# Altium schematic sheet Sensor.SchDoc
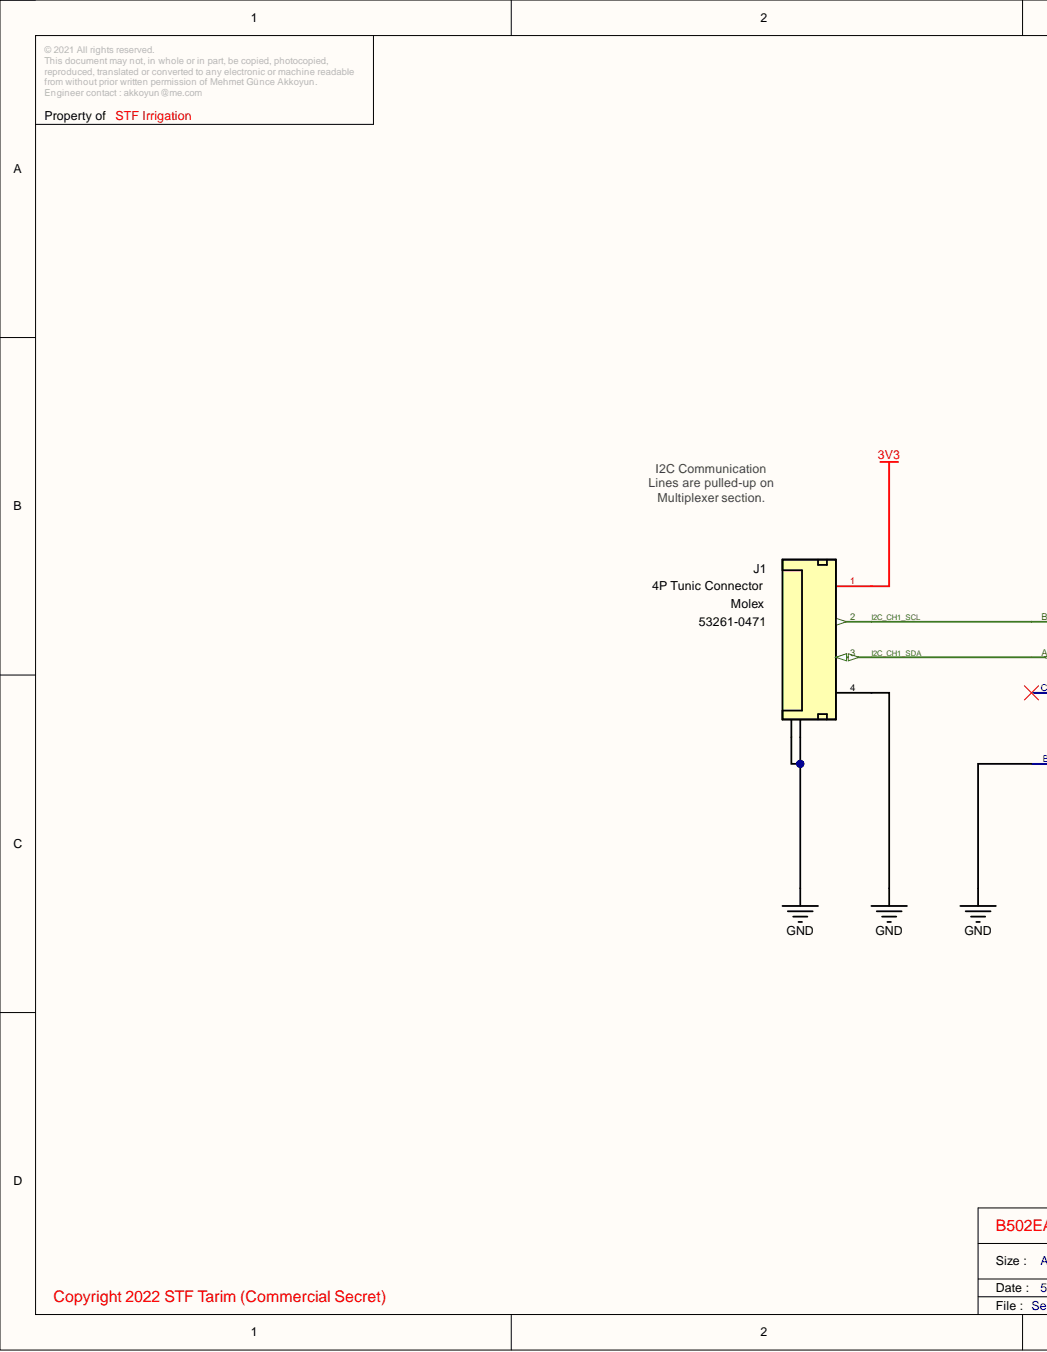
[diagram p0: Sensor.SchDoc, left]
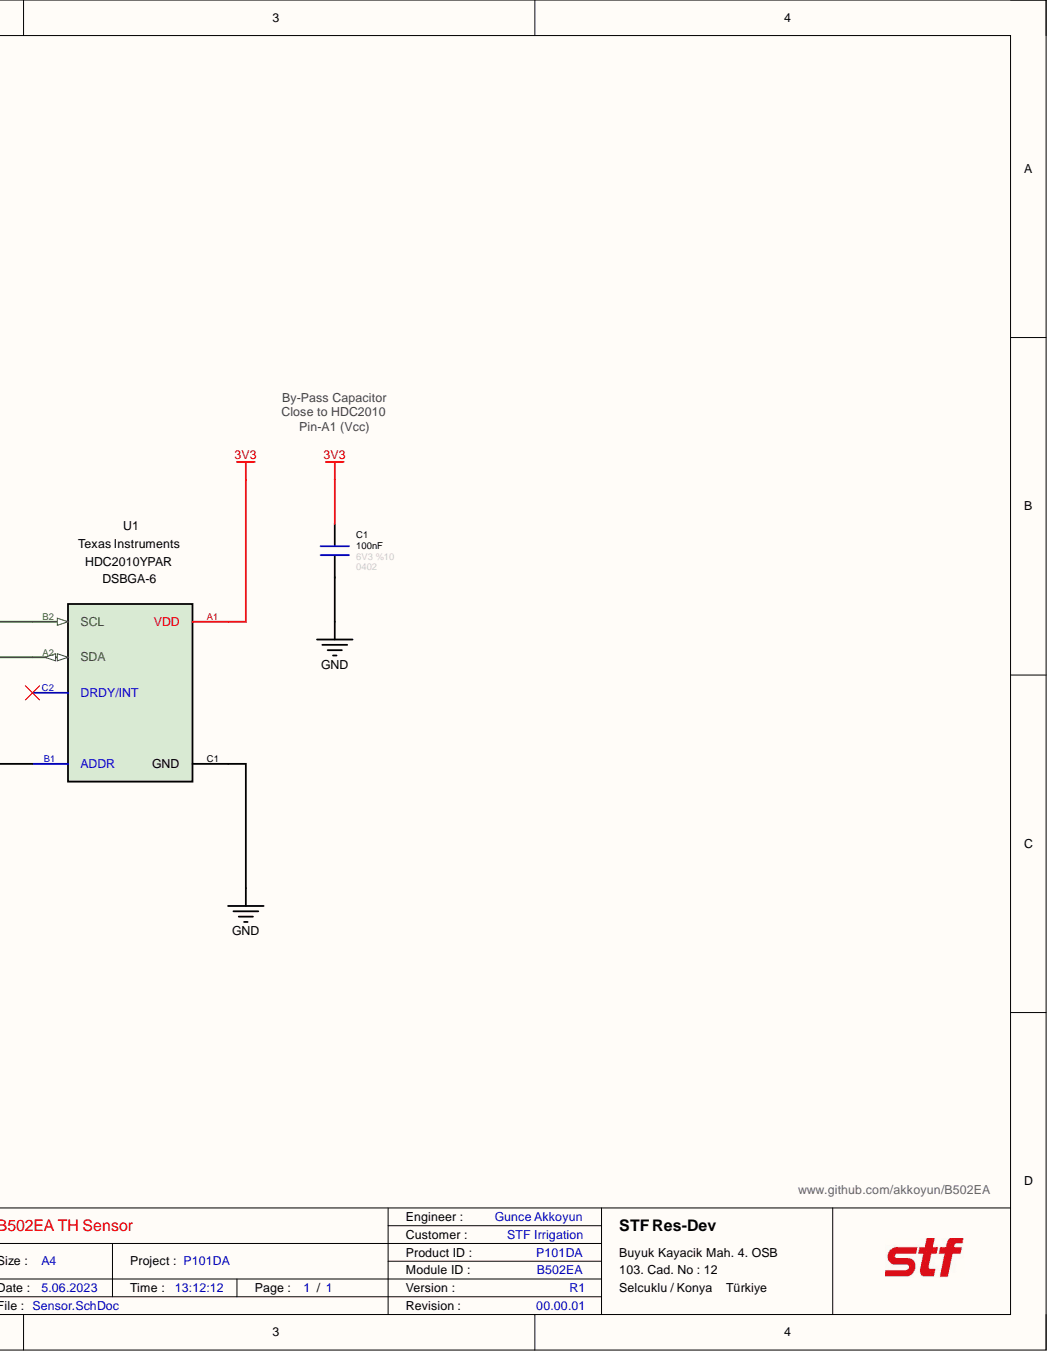
[diagram p1: Sensor.SchDoc, right]

## Parts
C1  value 100nF  Case: 0402  Tolerance: %10  Voltage: 6V3  [CAPACITOR_0402]  (hidden comment: Capacitor - 100nF [0402] (Ceramic))
J1  Manufacturer Name: Molex  Manufacturer Part Number: 53261-0471  System: Connector  [Connector_Tunic 4P]  (hidden comment: 4P SMD Tunic Connector)
U1  HDC2010YPAR  Case: DSBGA-6  [HDC2010]

## Nets
3V3: C1.2 J1.1 U1.A1(VDD)
GND: C1.1 J1.4 J1.5 J1.6 U1.B1(ADDR) U1.C1(GND)
I2C_CH1_SCL: J1.2 U1.B2(SCL)
I2C_CH1_SDA: J1.3 U1.A2(SDA)

Not connected: U1 C2(DRDY/INT)

## Library data of the parts
C1 = Capacitor - 100nF [0402-6V3]: Capacitor - 100nF [0402-6V3] (Ceramic); Manufacturer Name: AVX; Manufacturer Part Number: 04026D104KAT4A; Component Kind: Standard; ComponentLink1Description: Mouser; ComponentLink1URL: https://www.mouser.com.tr/ProductDetail/AVX/04026D104KAT4A?qs=sGAEpiMZZMs0AnBnWHyRQPg4iYkCw7IMED%2F4kpqgf7w%3D; ComponentLink2Description: DataSheet; ComponentLink2URL: https://www.mouser.com.tr/datasheet/2/40/cx5r-776519.pdf; Library Name: P101DA_Library.IntLib
J1 = Connector - Mini Tunic 4P (1.25): Mini Tunic Connector with 4P; Pitch: 1.25mm; Position: 4; Case: SMD; Component Kind: Standard; ComponentLink1Description: DataSheet; ComponentLink1URL: https://www.mouser.com.tr/datasheet/2/276/0532610471_PCB_HEADERS-170942.pdf; ComponentLink2Description: Mouser; ComponentLink2URL: https://www.mouser.com.tr/ProductDetail/Molex/53261-0471?qs=%2Fha2pyFaduhLhIsk8tywtK7BwWtguBSP4XxyDBTOTfs%3D; Library Name: P101DA_Library.IntLib; ROHS: Yes
U1 = IC - HDC2010: GEN 2 HUMIDITY SENSOR; Manufacturer Name: Texas Instruments; Component Kind: Standard; Library Name: P101DA_Library.IntLib; Manufacturer Part Number: HDC2010YPAR; Maximum Operating Temperature: + 85 C; Minimum Operating Temperature: - 40 C; Product Type: T/H Sensor
